# Altium schematic sheet updated_sami_board_v3.SchDoc
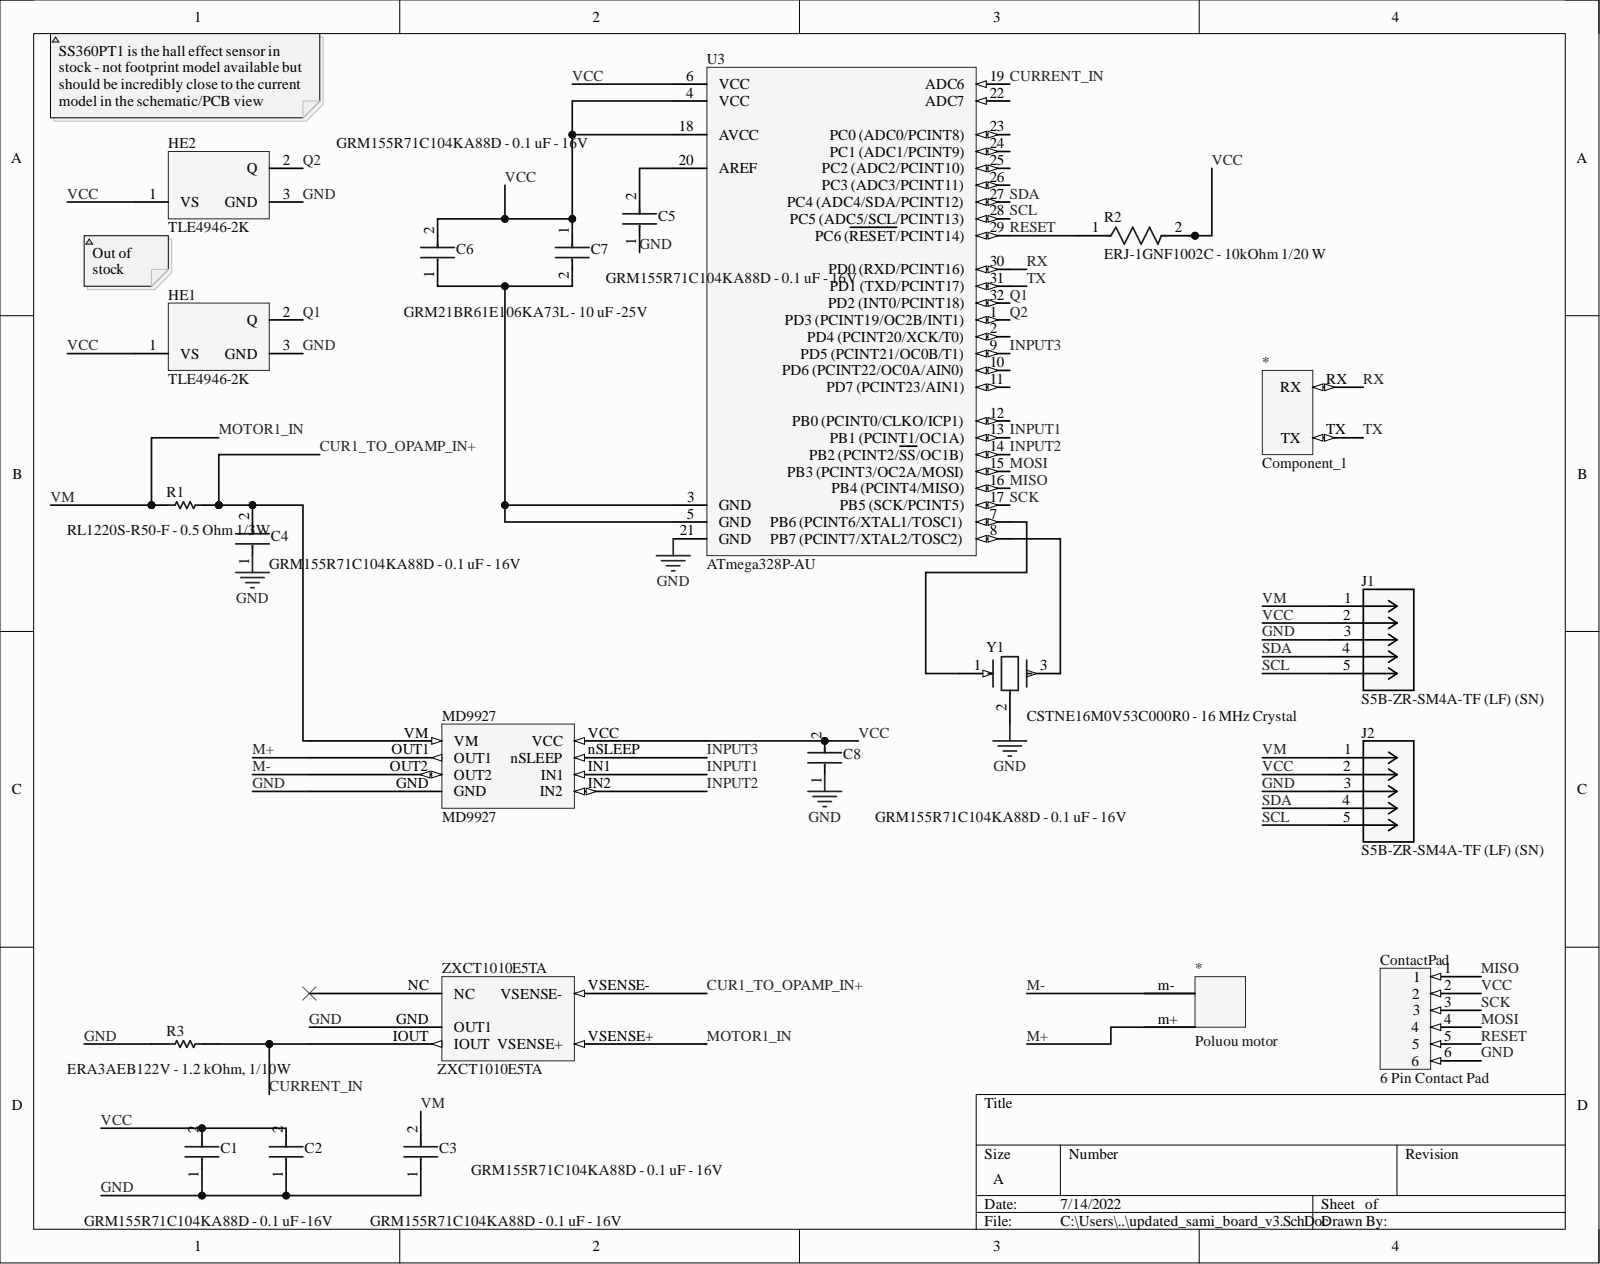
[diagram p0: updated_sami_board_v3.SchDoc]

## Parts
*  Component_1  [UART Header Pins]
*  Poluou motor  [PCBComponent_1]
C1  GRM155R71C104KA88D - 0.1 uF -16V  [FP-GRM15-MFG]
C2  GRM155R71C104KA88D - 0.1 uF - 16V  [FP-GRM15-MFG]
C3  GRM155R71C104KA88D - 0.1 uF - 16V  [FP-GRM15-MFG]
C4  GRM155R71C104KA88D - 0.1 uF - 16V  Manufacturer Part Number: GRM155R71C104KA88D  [FP-GRM15-MFG]
C5  GRM155R71C104KA88D - 0.1 uF - 16V  [FP-GRM15-MFG]
C6  GRM155R71C104KA88D - 0.1 uF - 16V  [FP-GRM15-MFG]
C7  GRM21BR61E106KA73L - 10 uF -25V  [FP-GRM21B-0_15-IPC_C]
C8  GRM155R71C104KA88D - 0.1 uF - 16V  [FP-GRM15-MFG]
ContactPad  6 Pin Contact Pad  [6PinContactPad]
HE1  TLE4946-2K  [INF-PG-SC59_M]
HE2  TLE4946-2K  [INF-PG-SC59_M]
J1  S5B-ZR-SM4A-TF (LF) (SN)  [CONN_S5B-ZR-SM4A-TF_JST]
J2  S5B-ZR-SM4A-TF (LF) (SN)  [CONN_S5B-ZR-SM4A-TF_JST]
MD9927  MD9927  [MD9927]
R1  RL1220S-R50-F - 0.5 Ohm 1/3W  Part Number: RL1220S-R50-F  [RESC2013X50X40NL20T20]
R2  ERJ-1GNF1002C - 10kOhm 1/20 W  Manufacturer Part Number: ERJ-1GNF1002C  [FP-ERJ1G-MFG]
R3  ERA3AEB122V - 1.2 kOhm, 1/10W  Part Number: ERA3AEB122V  [RESC1608X55X30ML20T20]
U3  ATmega328P-AU  [32A_L]
Y1  CSTNE16M0V53C000R0 - 16 MHz Crystal  [FP-CSTNE16M0V53C000R0-MFG]
ZXCT1010E5TA  ZXCT1010E5TA  [ZXCT1010E5TA]

## Nets
CUR1_TO_OPAMP_IN+: C4.2 MD9927.VM R1.2 ZXCT1010E5TA.VSENSE-
CURRENT_IN: R3.2 U3.19(ADC6) ZXCT1010E5TA.IOUT
GND: C1.1 C2.1 C3.1 C4.1 C5.1 C8.1 ContactPad.6 HE1.3 HE2.3 J1.3 J2.3 MD9927.GND R3.1 U3.21(GND) Y1.2 ZXCT1010E5TA.GND(OUT1)
INPUT1: MD9927.IN1 U3.13(PB1_(PCINT1/OC1A))
INPUT2: MD9927.IN2 U3.14(PB2_(PCINT2/SS/OC1B))
INPUT3: MD9927.nSLEEP U3.9(PD5_(PCINT21/OC0B/T1))
M+: *.m+ MD9927.OUT1
M-: *.m- MD9927.OUT2
MISO: ContactPad.1 U3.16(PB4_(PCINT4/MISO))
MOSI: ContactPad.4 U3.15(PB3_(PCINT3/OC2A/MOSI))
MOTOR1_IN: C3.2 J1.1 J2.1 R1.1 ZXCT1010E5TA.VSENSE+
Q1: HE1.2 U3.32(PD2_(INT0/PCINT18))
Q2: HE2.2 U3.1(PD3_(PCINT19/OC2B/INT1))
RESET: ContactPad.5 R2.1 U3.29(PC6_(RESET/PCINT14))
RX: *.RX U3.30(PD0_(RXD/PCINT16))
SCK: ContactPad.3 U3.17(PB5_(SCK/PCINT5))
SCL: J1.5 J2.5 U3.28(PC5_(ADC5/SCL/PCINT13))
SDA: J1.4 J2.4 U3.27(PC4_(ADC4/SDA/PCINT12))
TX: *.TX U3.31(16V_(TXD/PCINT17))
VCC: C1.2 C2.2 C6.2 C7.1 C8.2 ContactPad.2 HE1.1 HE2.1 J1.2 J2.2 MD9927.VCC R2.2 U3.4(VCC) U3.6(VCC) U3.18(AVCC)
NetC5_2: C5.2 U3.20(AREF)
NetC6_1: C6.1 C7.2 U3.3(GND) U3.5(GND)
NetU3_7: U3.7 Y1.1
NetU3_8: U3.8 Y1.3

Not connected: U3 2(PD4_(PCINT20/XCK/T0)) 10(PD6_(PCINT22/OC0A/AIN0)) 11(PD7_(PCINT23/AIN1)) 12(PB0_(PCINT0/CLKO/ICP1)) 22(ADC7) 23(PC0_(ADC0/PCINT8)) 24(PC1_(ADC1/PCINT9)) 25 26(PC3_(ADC3/PCINT11)); ZXCT1010E5TA NC

## Library data of the parts
C1-C6 C8 = CMP-2100-03641-2: CAP CER 0.1UF 16V X7R 0402; Manufacturer: Murata; Capacitance: 0.10μF; Material: Ceramic; Standoff Height: 0mm; Tolerance: 10%; Value: 0.10μF; Voltage Rating: 16Vdc; Category: Cap; Component Kind: Standard; ComponentLink2Description: Datasheet; ComponentLink2URL: https://search.murata.co.jp/Ceramy/image/img/A01X/G101/ENG/GRM155R71H471KA01-01A.pdf; Device Class L1: Passive Components; Device Class L2: Capacitors; Device Class L3: Ceramic Capacitors; Digikey Description: CAP CER 0.1UF 16V X7R 0402; Height: 0.55mm; Ipc Land Pattern Name: CAPC10050X219; Lead Free: yes; Library Name: Altium Content Vault; Mouser Description: 0402 0.1 uF 16V ±10 % Tolerance X7R SMT Multilayer Ceramic Capacitor; Octopart: https://octopart.com/grm155r71c104ka88d-murata-196880; Package: GRM15; RoHS: TRUE; Temperature: -55°C to +125°C; Temperature Characteristic: X7R; Temperature Range High: +125°C; Temperature Range Low: -55°C
  C1-C3 C5 C6 C8: Manufacturer Part Number: GRM155R71C104KA88D
C7 = CMP-2007-03541-2: Chip Multilayer Ceramic Capacitors for General Purpose, 0805, 10uF, X5R, 15%, 10%, 25V; Capacitance: 10uF; Case Code (Imperial): 0805; Case Code (Metric): 2012; Case/Package: 0805; Component Kind: Standard; Depth: 1.25mm; Dielectric: X5R; Height: 1.25mm; Lead Free: Lead Free; Length: 2mm; Library Name: Altium Content Vault; Material: Ceramic; Max Operating Temperature: 85°C; Min Operating Temperature: -55°C; Mount: Surface Mount; Package Quantity: 1; Packaging: Tape and Reel; Radiation Hardening: No; Termination: SMD/SMT; Thickness: 0.053inch; Tolerance: 10%; Voltage: 25V; Voltage Rating: 25V; Voltage Rating (DC): 25V; Width: 0.049inch
HE1 HE2 = CMP-1148-00006-1: High Precision Hall Effect Latch, PG-SC59, Reel, Green; Manufacturer: Infineon; Bhyst (25degreesC) max: 4Â mT; Bop (25degreesC) min: 2Â mT; Brp (25degreesC) typ: -2Â mT; Chopped Hall Technology: TRUE; Direction Detection: FALSE; Interface: open collector; Target Application: Automotive; Component Kind: Standard; ComponentLink1Description: Manufacturer URL; ComponentLink1URL: http://www.infineon.com/; ComponentLink2Description: Datasheet; ComponentLink2URL: http://www.infineon.com/dgdl/TLE4946-2K_DS_Rev1.pdf?folderId=db3a30431f848401011facc1c83b4674&fileId=db3a30431f848401011fbc925c48637f; ComponentLink3Description: Package Specification; ComponentLink3URL: http://www.infineon.com/dgdl/SC59-Package_Overview.pdf?folderId=db3a30431936bc4b01194dc0d34440b0&fileId=db3a30431936bc4b01194dd1aa1d40cf; DatasheetVersion: Rev. 1.0; Green: TRUE; Library Name: Altium Content Vault; Mounting Technology: Surface Mount; PackageDescription: 3-Pin Semiconductor Package, 3 x 1.53 mm Body, 0.95 mm Pitch; PackageReference: PG-SC59; PackageVersion: Mar-08; Packing: Reel; PartNumber: TLE4946-2K; Type: Latch
J1 J2 = S5B-ZR-SM4A-TF (LF) (SN): No Description Available; Mfr_Name: JST; Component Kind: Standard; Library Name: S5B-ZR-SM4A-TF_LF__SN_.SchLib; Manufacturer_Part_Number: S5B-ZR-SM4A-TF (LF) (SN); RefDes: RefDes; Type: DEV; Copyright: Copyright (C) 2021 Ultra Librarian. All rights reserved.
R1 = CMP-2001-03168-1: Manufacturer: Susumu; Component Kind: Standard; Library Name: Altium Content Vault
R2 = CMP-2004-03445-2: RES SMD 10K OHM 1% 1/20W 0201; Manufacturer: Panasonic Electronic Components; Automotive Grade: Grade 1; Power Rating: 0.05W; Resistance: 10kΩ; Temperature Coefficient: 200ppm/°C; Value: 10kΩ; Voltage Rating: 25V; Automotive: Yes; Category: Res; Component Kind: Standard; ComponentLink2Description: Datasheet; ComponentLink2URL: https://industrial.panasonic.com/cdbs/www-data/pdf/RDA0000/AOA0000C304.pdf; Composition: Thick Film; Device Class L1: Passive Components; Device Class L2: Resistors; Device Class L3: Chip SMD Resistors; Digikey Description: RES SMD 10K OHM 1% 1/20W 0201; Footprint Url: https://industrial.panasonic.com/cdbs/www-data/pdf/RDA0000/DMA0000COL17.pdf; Height: 0.26mm; Lead Free: Yes; Library Name: Altium Content Vault; Mouser Description: Thick Film Resistors - SMD 0201 10Kohm 1% HalogenFree AEC-Q200; Octopart: https://octopart.com/erj-1gnf1002c-panasonic-55419697; Package: ERJ1G; RoHS: TRUE; Series: ERJ; Temperature: -55°C to +125°C; Temperature Range High: +125°C; Temperature Range Low: -55°C; Tolerance: 1%
R3 = CMP-2000-00897-1: Manufacturer: Panasonic; Component Kind: Standard; Library Name: Altium Content Vault
U3 = CMP-0095-00261-2: 8-bit AVR Microcontroller, 32KB Flash, 1KB EEPROM, 2KB SRAM, 32-pin TQFP, Industrial Grade (-40°C to 85°C); Code_JEDEC: MS-026-ABA; Component Kind: Standard; ComponentLink1Description: Manufacturer URL; ComponentLink1URL: http://www.atmel.com/; ComponentLink2Description: ATmega48A/48PA/88A/88PA/168A/168PA/328/328P Datasheet; ComponentLink2URL: http://www.atmel.com/dyn/resources/prod_documents/doc8271.pdf; ComponentLink3Description: ATmega48A/48PA/88A/88PA/168A/168PA/328/328P Summary; ComponentLink3URL: http://www.atmel.com/dyn/resources/prod_documents/8271S.pdf; DatasheetVersion: revD, May-2011; Library Name: Altium Content Vault; PackageDescription: 32-Lead, 7x7x1.0 mm Body, 0.8mm Lead Pitch, Thin Profile Plastic Quad Flat Package (TQFP); PackageReference: 32A; PackageVersion: revC, Oct-2010; RevisionNote: DatasheetVersion & PackageVersion updated. URLs added.
Y1 = CMP-06057-000009-1: CERAMIC RES 16.0000MHZ 15PF SMD; Frequency: 16MHz; Frequency Stability: 0.15%; Frequency Tolerance: 0.5%; Impedance: 40R; Capacitance: 15pF; Case/Package: SMD/SMT; Component Kind: Standard; Library Name: Altium Content Vault; Max Operating Temperature: 125°C; Min Operating Temperature: -40°C; Mount: Surface Mount; Packaging: Tape and Reel; Resistor Type: Ceramic
* = Component_1: Component Kind: Standard; Library Name: UART Header Pins.IntLib
* = Poluou motor: Component Kind: Standard; Library Name: polulu_motor.SchLib
ContactPad = 6 Pin Contact Pad: Component Kind: Standard; Library Name: 6PinContactPad.SchLib
MD9927 = MD9927: Component Kind: Standard; Library Name: MD9927.SchLib
ZXCT1010E5TA = ZXCT1010E5TA: Component Kind: Standard; Library Name: ZXCT1010E5TA.SchLib
